# Altium schematic sheet PartialSchematicLab1Part2.SchDoc
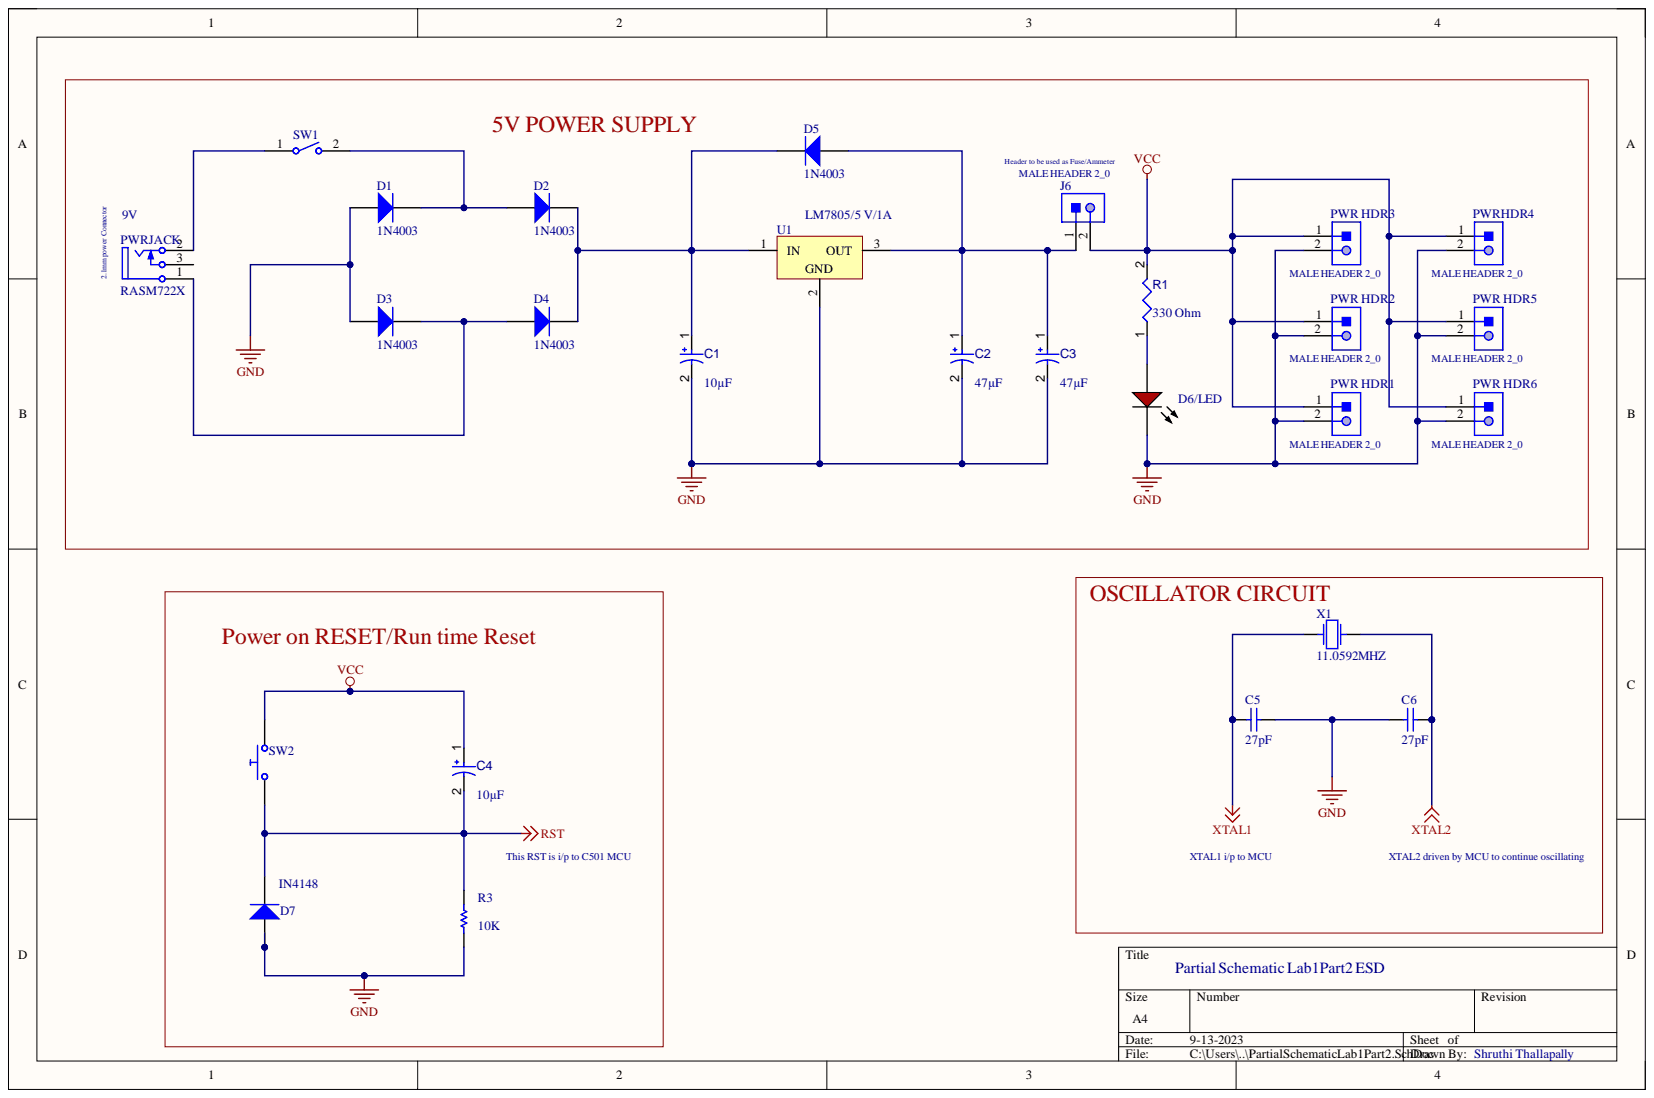
[diagram p0: PartialSchematicLab1Part2.SchDoc]

## Parts
C1  value 10µF  [CAPPR65-350-1150X800X1250]  (hidden comment: ECA1HM101)
C2  value 47µF  [CAPPR65-350-1150X800X1250]  (hidden comment: ECA1HM101)
C3  value 47µF  [CAPPR65-350-1150X800X1250]  (hidden comment: ECA1HM101)
C4  value 10µF  [CAPPR65-350-1150X800X1250]  (hidden comment: ECA1HM101)
C5  value 27pF  (hidden comment: Capacitor)
C6  value 27pF  (hidden comment: Capacitor)
D1  1N4003  [FAIR-DO-41-2]
D2  1N4003  [FAIR-DO-41-2]
D3  1N4003  [FAIR-DO-41-2]
D4  1N4003  [FAIR-DO-41-2]
D5  1N4003  [FAIR-DO-41-2]
D6/LED  (no value)  [LED-2P-2.28-3MM_A]
D7  Diode  (value not drawn)
J6  (no value)  [JST-S2B-XH-A(LF)(SN)_V]
PWR_HDR1  (no value)  [JST-S2B-XH-A(LF)(SN)_V]
PWR_HDR2  (no value)  [JST-S2B-XH-A(LF)(SN)_V]
PWR_HDR3  (no value)  [JST-S2B-XH-A(LF)(SN)_V]
PWR_HDR5  (no value)  [JST-S2B-XH-A(LF)(SN)_V]
PWR_HDR6  (no value)  [JST-S2B-XH-A(LF)(SN)_V]
PWRHDR4  (no value)  [JST-S2B-XH-A(LF)(SN)_V]
PWRJACK  RASM722X  [SWIT-RASM722X_V]
R1  value 330 Ohm  [RESC0402(1005)_L]  (hidden comment: 330R2J)
R3  value 10K  (hidden comment: Resistor)
SW1  (no value)  [OMRON-B3U-3000P_V]
SW2  Push Button Normally Opened  (value not drawn)
U1  (no value)  [TO220]
X1  F0: 11.0592MHZ  (hidden comment: Crystal)

## Nets
GND: C1.2 C2.2 C3.2 C5.2 C6.1 D1.1 D3.1 D6/LED.2 D7.1 PWR_HDR1.2 PWR_HDR2.2 PWR_HDR3.2 PWR_HDR5.2 PWR_HDR6.2 PWRHDR4.2 R3.1 U1.2
RST: C4.2 D7.2 R3.2 SW2.1
VCC: C4.1 J6.2 PWR_HDR1.1 PWR_HDR2.1 PWR_HDR3.1 PWR_HDR5.1 PWR_HDR6.1 PWRHDR4.1 R1.2 SW2.2
XTAL1: C5.1 X1.1
XTAL2: C6.2 X1.2
NetC1_1: C1.1 D2.2 D4.2 D5.2 U1.1
NetC2_1: C2.1 C3.1 D5.1 J6.1 U1.3
NetD1_2: D1.2 D2.1 SW1.2
NetD3_2: D3.2 D4.1 PWRJACK.1
NetD6/LED_1: D6/LED.1 R1.1
NetPWRJACK_2: PWRJACK.2 SW1.1

Not connected: PWRJACK 3

## Library data of the parts
C1-C4 = CMP-001-00026-7: Aluminum Electrolytic Capacitor, 100 uF, +/- 20%, 50 V, -40 to 85 degC, 2-Pin THD, RoHS, Bulk; Manufacturer: Panasonic; Ripple Current: 250mA; Voltage Rating: 50V; Capacitor Type: Electrolytic; Case/Package: Radial; Component Kind: Standard; Datasheet URL: https://industrial.panasonic.com/cdbs/www-data/pdf/RDF0000/ABA0000C1218.pdf; Datasheet Version: Rev. 05, 10/2016; Diameter: 8mm; Lead Pitch: 3.5mm; Manufacturer URL: http://www.panasonic.com/; Max Operating Temperature: 85°C; Min Operating Temperature: -40°C; Mounting Technology: TH; Package Description: 2-Pin Radial Lead, Dia 8 mm, Height 12.5 mm; Package Quantity: 200; Package Reference: CAPPR65-350-1150X800X1250; Packaging: Bulk; Pins: 2; Ripple Current (AC): 250mA; RoHS Compliant: True; Tolerance: 20%; Library Name: Shruthi Thallapally
C5 C6 = Capacitor: SimulationGenericComponent: True; Component Kind: Standard; Description: Capacitor; Library Name: Simulation Generic Components.IntLib; Simulation: C_Capacitor_Default
D1-D5 = CMP-004-00004-2: General Purpose Rectifier, 400 V, 1 A, -55 to 175 degC, 2-Pin DO-204AL (DO-41), RoHS, Tape and Reel; Manufacturer: Fairchild Semiconductor; Forward Current: 1A; Forward Voltage: 1.1V; Max Surge Current: 30A; Package Version: Rev. 2, 09/2008; Peak Reverse Current: 50A; Case/Package: DO-41-2; Component Kind: Standard; Datasheet URL: https://www.fairchildsemi.com/datasheets/1N/1N4004.pdf; Datasheet Version: Rev. 1.1.0, 11/2014; Diameter: 2.72mm; Manufacturer URL: https://www.fairchildsemi.com/; Max Operating Temperature: 175°C; Min Operating Temperature: -55°C; Mounting Technology: TH; Package Description: 2-Pin Axial Lead, Dia 2.375 mm, Body Length 4.63 mm; Package Quantity: 5000; Package Reference: DO-41-2; Package URL: http://www.fairchildsemi.com/dwg/DO/DO41A.pdf; Packaging: TapeandReel; Pins: 2; RoHS Compliant: True; Library Name: Shruthi Thallapally
D6/LED = CMP-007-00007-2: Red LED, 80 mW, 30 mA, -25 to 85 degC, 2-Pin THD; Manufacturer: Shenzhen CGX; Case/Package: 304090041; Component Kind: Standard; Datasheet URL: http://datasheet.octopart.com/304090041-Chengguangxing-datasheet-62330656.pdf; Datasheet Version: Sep-14; Manufacturer URL: http://szcgx.com/; Mounting Technology: TH; Package Description: 2-Pin Through Hole Device, Dia 3 mm, Pitch 2.28 mm; Package Reference: 304090041; RoHS Compliant: Unknown; Library Name: Shruthi Thallapally
D7 = Diode: AF: 1; BV: 0; BV_MAX: 1e99; EG: 1.11; FC: 0.5; IBV: 0.001; IS: 1e-14; MJSW: 0.33; NTUN: 30; SimulationGenericComponent: True; TNOM: 27; XTI: 3; CJO: 0; CJP: 0; Component Kind: Standard; CTA: 0; CTP: 0; Description: Diode; FCS: 0.5; FV_MAX: 1e99; IKF: 0; IKR: 0; ISR: 1e-14; JSW: 0; JTUN: 0; JTUNSW: 0; KEG: 1; KF: 0; LEVEL: 1; Library Name: Simulation Generic Components.IntLib; N: 1; NBV: 1; NR: 1; NS: 1; PHP: 1; RS: 0; Simulation: diode; TCV: 0; TLEV: 0; TLEVC: 0; TM1: 0; TM2: 0; TPB: 0; TPHP: 0; TRS: 0; TRS2: 0; TT: 0; TTT1: 0; TTT2: 0; VJ: 1; XTITUN: 3
J6 PWR_HDR1-PWR_HDR3 PWR_HDR5 PWR_HDR6 PWRHDR4 = CMP-002-00032-2: Male Header, Pitch 2.5 mm, 1 x 2 Position, Height 6.1 mm, Tail Length 3.4 mm, -25 to 85 degC, RoHS, Bulk; Manufacturer: JST; Case/Package: S2B-XH-A(LF)(SN); Component Kind: Standard; Connector Type: Header; Datasheet URL: http://www.jst-mfg.com/product/pdf/eng/eXH.pdf; Datasheet Version: May-16; Gender: Male; Manufacturer URL: http://www.jst.com/home2.html; Max Operating Temperature: 85°C; Min Operating Temperature: -25°C; Mounting Technology: TH; Package Description: XH Series Disconnectable Crimp Style Connector, Pitch 2.5 mm, 1 x 2 Position, Height 6.1 mm, Tail Length 3.4 mm; Package Reference: S2B-XH-A(LF)(SN); RoHS Compliant: Unknown; Library Name: Shruthi Thallapally
PWRJACK = CMP-002-00013-2: Power Jack, 5 A, 250 V, 3-Pin SMD, RoHS, Bulk; Manufacturer: Switchcraft; Connector Type: DCJack; Case/Package: RASM722X; Component Kind: Standard; Datasheet URL: http://www.switchcraft.com/Drawings/rasm732x_cd.pdf; Datasheet Version: Rev. A, 09/2005; Manufacturer URL: http://www.switchcraft.com/; Mounting Technology: SM; Package Description: 3-Pin Surface Mount Device, Body 14.5 x 9 mm; Package Reference: RASM722X; RoHS Compliant: Unknown; Library Name: Shruthi Thallapally
R1 = CMP-009-00095-2: 330R 0.063W 5% 0402 (1005 Metric)  SMD; Power: 63mW; Case/Package: 0402; Component Kind: Standard; Mounting Technology: SMT; Pins: 2; Tolerance: 5%; Library Name: Shruthi Thallapally
R3 = Resistor: SimulationGenericComponent: True; TC1: 0; Component Kind: Standard; Description: Resistor; Library Name: Simulation Generic Components.IntLib; Simulation: R_Resistor_Default; TC2: 0
SW1 = CMP-011-00005-2: Tactile Switch, SPST-NO, 0.05 A, 12 V, -25 to 70 degC, 2-Pin SMD, RoHS, Tape and Reel; Manufacturer: Omron Electronic Components; Contact Current Rating: 50mA; IP Rating: IP40; Max Contact Resistance: 100mohm; Max Voltage Rating (DC): 12V; Operating Force: 162gf; Throw Configuration: SPST; Case/Package: B3U-3000P; Component Kind: Standard; Contact Material: Silver; Current Rating: 50mA; Datasheet URL: https://www.omron.com/ecb/products/pdf/en-b3u.pdf; Datasheet Version: Jan-15; Manufacturer URL: http://www.omron.com/; Max Current Rating: 50mA; Max Operating Temperature: 70°C; Min Operating Temperature: -25°C; Mounting Technology: SM; Package Description: 2-Pin Surface Mount Device, Body 4 x 3.2 mm; Package Quantity: 4000; Package Reference: B3U-3000P; Packaging: TapeandReel; RoHS Compliant: True; Voltage Rating: 12V; Voltage Rating (DC): 12V; Library Name: Shruthi Thallapally
SW2 = Push Button NO: closed: 0; Rclosed: 1m; Ropen: 1Meg; SimulationGenericComponent: True; Component Kind: Standard; Description: Push Button Normally Opened; Library Name: Simulation Generic Components.IntLib; Simulation: PUSH_BUTTON_NO
U1 = CMP-019-00018-3: Positive Voltage Regulator IC, 1.5 A, 5 V, 0 to 125 degC, 3-Pin TO-220, RoHS, Tube; Manufacturer: STMicroelectronics; Dropout Voltage: 2V; Max Input Voltage: 35V; Max Ouput Current: 1.5A; Max Supply Voltage: 20V; Min Input Voltage: 7V; Min Supply Voltage: 8V; Nominal Output Voltage: 5V; Outputs: 1; Case/Package: TO-220; Component Kind: Standard; Datasheet URL: https://datasheet.ciiva.com/15111/getdatasheetpartid-44136-15111027.pdf; Datasheet Version: Rev 30, 09/2012; Manufacturer URL: http://www.st.com/; Max Operating Temperature: 125°C; Min Operating Temperature: 0°C; Mounting Technology: TH; Output Current: 1.5A; Output Voltage: 5V; Package Quantity: 50; Packaging: Rail/Tube; Pins: 3; RoHS Compliant: True; Library Name: Shruthi Thallapally
X1 = Crystal: Q: 50K; R: 500; SimulationGenericComponent: True; Component Kind: Standard; Description: Crystal; Library Name: Simulation Generic Components.IntLib; Simulation: CRYSTAL
